# Altium schematic sheet 03_DpLED.SchDoc(03_DpLED)
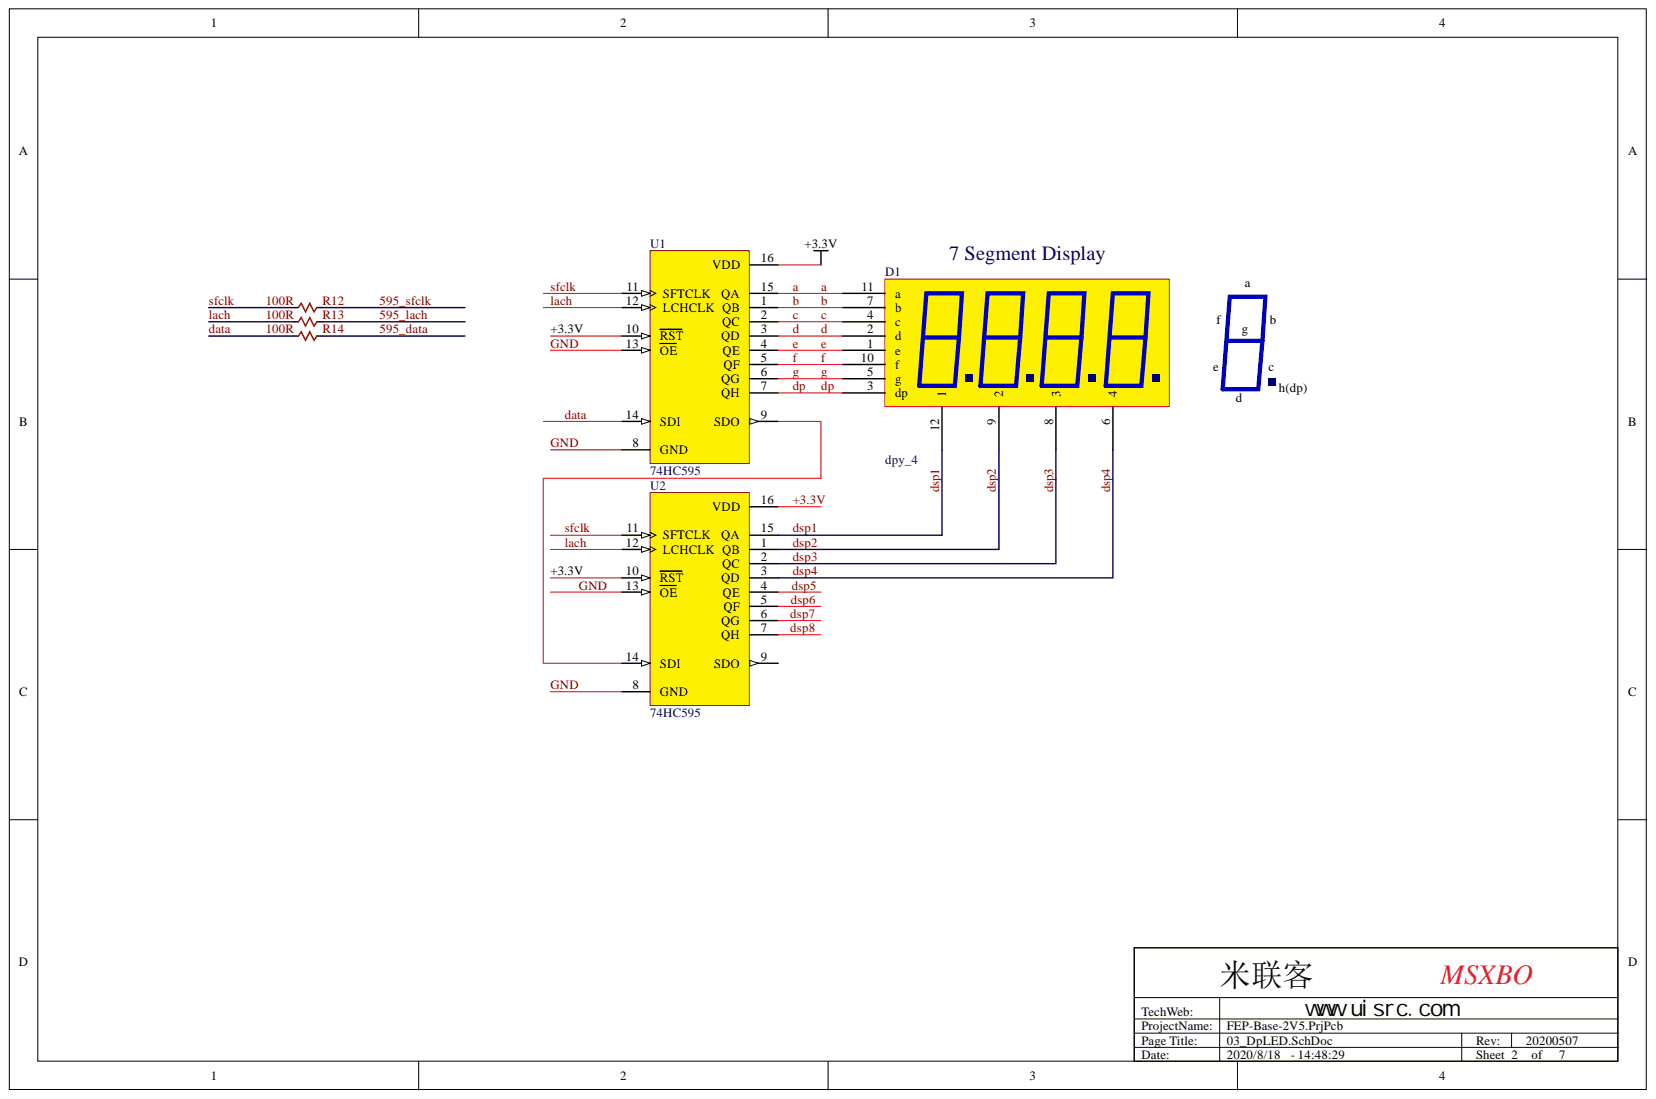
[diagram p0: 03_DpLED.SchDoc]

## Parts
D1  dpy_4  [DPY_4_1]
R12  100R  [RES0402]
R13  100R  [RES0402]
R14  100R  [RES0402]
U1  74HC595  [SOP16W]
U2  74HC595  [SOP16W]

## Nets
595_DATA: R14.2
595_LACH: R13.2
595_SFCLK: R12.2
+3.3V: U1.10(RST) U1.16(VDD) U2.10(RST) U2.16(VDD)
A: D1.11(a) U1.15(QA)
B: D1.7(b) U1.1(QB)
C: D1.4(c) U1.2(QC)
D: D1.2(d) U1.3(QD)
DATA: R14.1 U1.14(SDI)
DP: D1.3(dp) U1.7(QH)
DSP1: D1.12 U2.15(QA)
DSP2: D1.9 U2.1(QB)
DSP3: D1.8 U2.2(QC)
DSP4: D1.6 U2.3(QD)
DSP5: U2.4(QE)
DSP6: U2.5(QF)
DSP7: U2.6(QG)
DSP8: U2.7(QH)
E: D1.1(e) U1.4(QE)
F: D1.10(f) U1.5(QF)
G: D1.5(g) U1.6(QG)
GND: U1.8(GND) U1.13(OE) U2.8(GND) U2.13(OE)
LACH: R13.1 U1.12(LCHCLK) U2.12(LCHCLK)
SFCLK: R12.1 U1.11(SFTCLK) U2.11(SFTCLK)
NetU1_9: U1.9(SDO) U2.14(SDI)

Not connected: U2 9(SDO)

## Library data of the parts
R12-R14 = RESISTOR: Generic Resistor; Value: 390; Component Kind: Standard; Library Name: Miz702fun_20160501.IntLib
D1 = dpy_4: Component Kind: Standard; Library Name: FEP-Base-3V3.SCHLIB
U1 U2 = 74HC595: Component Kind: Standard; Library Name: FEP-Base-3V3.SCHLIB
